# Altium schematic sheet DIP Switch.SchDoc("DIP Switch")
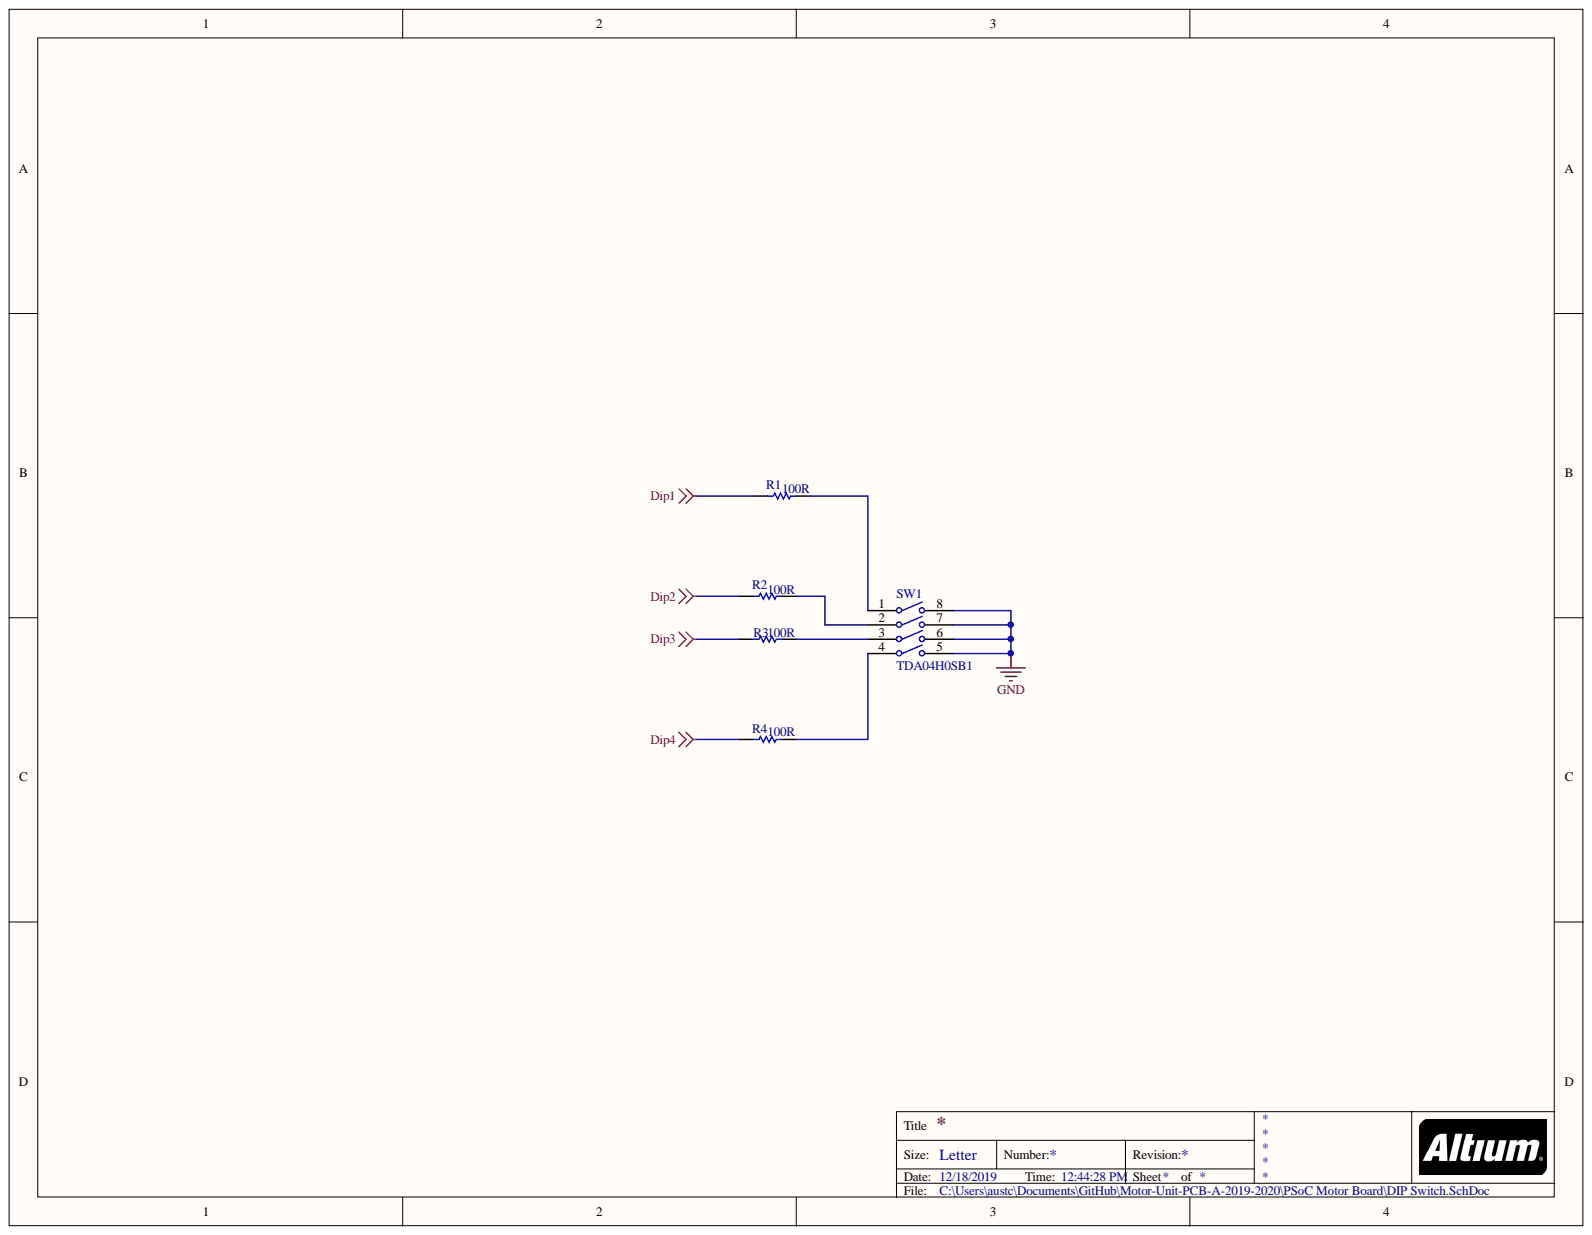
[diagram p0: DIP Switch.SchDoc]

## Parts
R1  100R  [RESC0805(2012)_N]
R2  100R  [RESC0805(2012)_N]
R3  100R  [RESC0805(2012)_N]
R4  100R  [RESC0805(2012)_N]
SW1  TDA04H0SB1  [CKCP-TDA04H0SB1R_V]

## Nets
Dip1: R1.1
Dip2: R2.1
Dip3: R3.1
Dip4: R4.1
GND: SW1.5 SW1.6 SW1.7 SW1.8
NetR1_2: R1.2 SW1.1
NetR2_2: R2.2 SW1.2
NetR3_2: R3.2 SW1.3
NetR4_2: R4.2 SW1.4

## Library data of the parts
R1-R4 = 120R 5% 0805: 120R 0.125W 5% 0805 (2012 Metric)  SMD; Value: 120.00 Ohm; Case-Metric: 2012; Case-EIA: 0805; Tolerance: 5 %; Technology: SMT; Power: 0.125 W; Component Kind: Standard; Library Name: Husky Robotics Standard Library 2019-2020.IntLib
SW1 = SMD Half-Pitch Dip Switch Array: Ultra-Miniature Surface Mount Half-Pitch DIP Switch, 25 mA, 24 V, -40 to 85 degC, 8-Pin SMD, RoHS, Tube; Voltage Rating (DC): 24 V; Throw Configuration: SPST; Max Current Rating: 25 mA; Max Contact Resistance: 100 mohm; Current Rating: 100 mA; RoHSCompliant: true; REACH SVHC: No SVHC; Radiation Hardening: No; Pins: 4; Packaging: Rail/Tube; PackageReference: TDA04H0SB1R; Package Quantity: 70; PackageDescription: 8-Pin Surface Mount Device, Body 6.21 x 6.2 mm, Pitch 1.27 mm; Mounting Technology: Surface Mount; Mount: Surface Mount; Min Operating Temperature: -40 degC; Max Voltage Rating (DC): 24 V; Max Operating Temperature: 85 degC; Length: 6.21 mm; Lead Pitch: 1.27 mm; Height: 2.3 mm; DatasheetVersion: 02/2016; Contact Plating: Gold; Contact Material: Copper; Contact Finish: Gold; Contact Current Rating: 100 mA; ComponentLink1URL: http://www.ck-components.com/media/1328/tda.pdf; ComponentLink1Description: Datasheet; Component Kind: Standard; Case\Package: DIP; Library Name: Husky Robotics Standard Library 2019-2020.IntLib
